# Altium schematic sheet AD936X_PMU.SchDoc(AD936X_PMU)
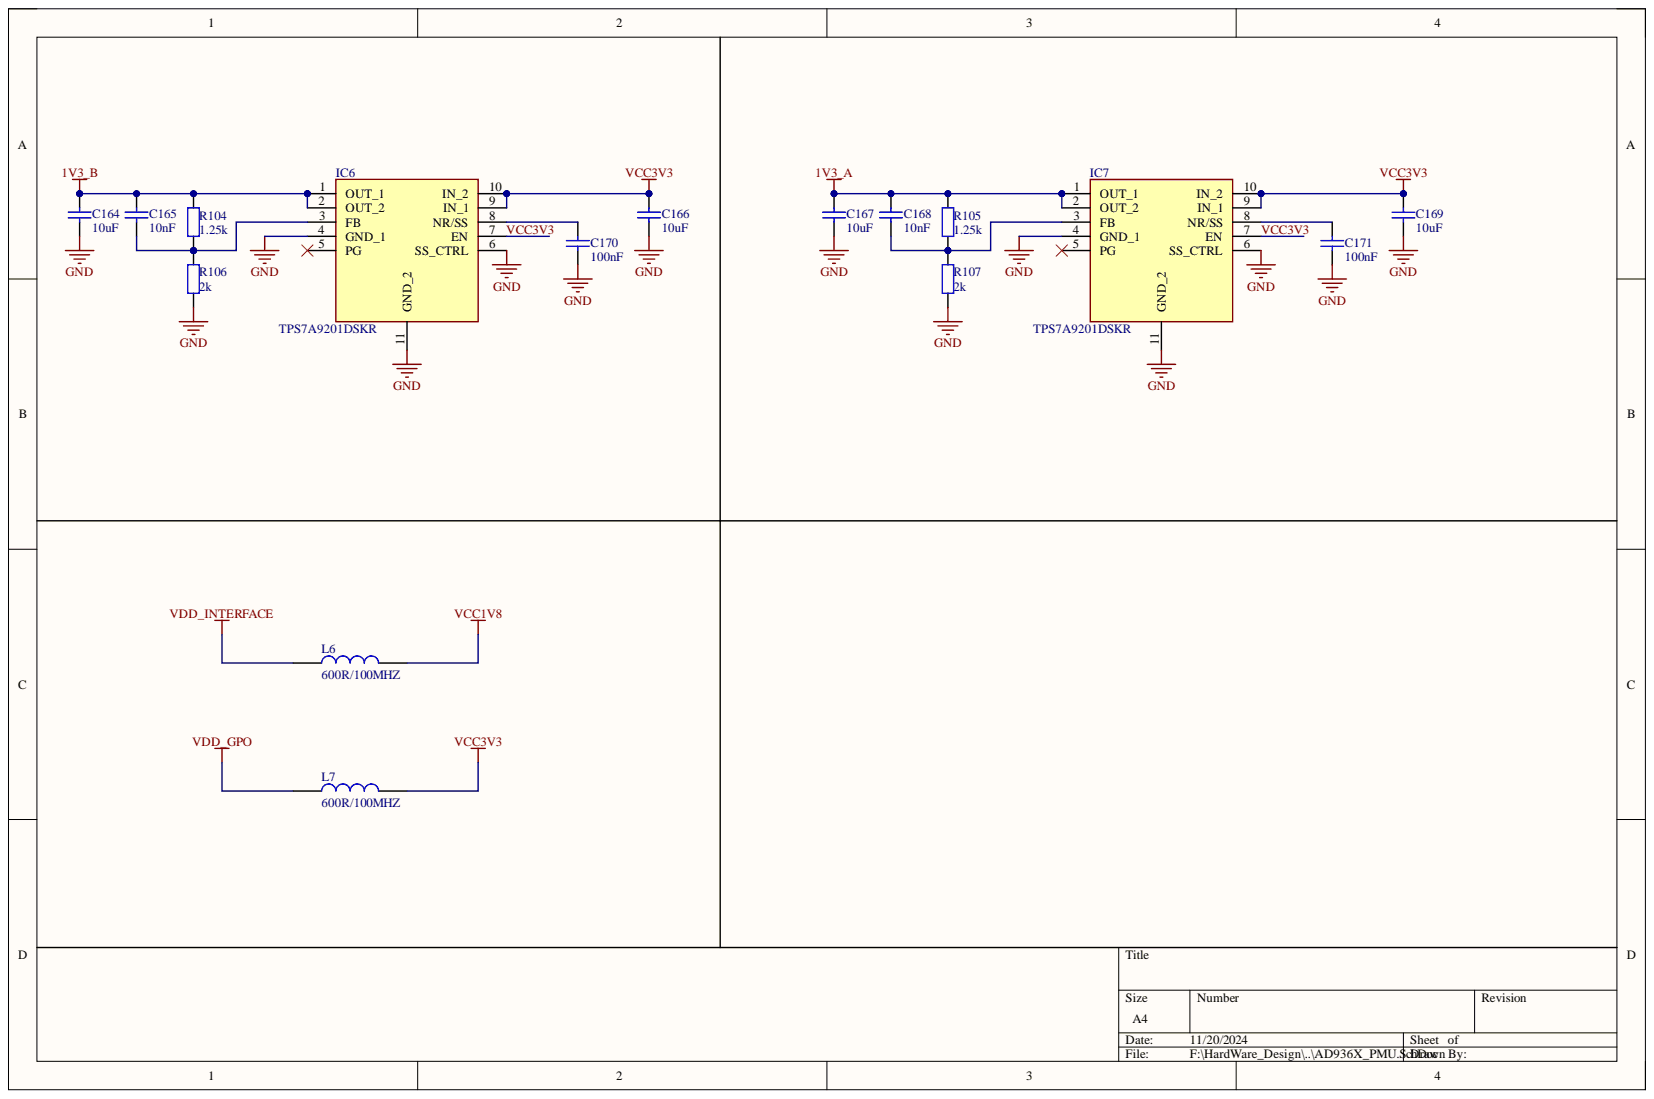
[diagram p0: AD936X_PMU.SchDoc]

## Parts
C164  10uF  [C 0603_L]
C165  10nF  [C 0402_L]
C166  10uF  [C 0603_L]
C167  10uF  [C 0603_L]
C168  10nF  [C 0402_L]
C169  10uF  [C 0603_L]
C170  100nF  [C 0402_L]
C171  100nF  [C 0402_L]
IC6  TPS7A9201DSKR  [SON50P250X250X80-11N-D]
IC7  TPS7A9201DSKR  [SON50P250X250X80-11N-D]
L6  600R/100MHZ  [L 0805]
L7  600R/100MHZ  [L 0805]
R104  1.25k  [R 0402_L]
R105  1.25k  [R 0402_L]
R106  2k  [R 0402_L]
R107  2k  [R 0402_L]

## Nets
1V3_A: C167.2 C168.2 IC7.1(OUT_1) IC7.2(OUT_2) R105.2
1V3_B: C164.2 C165.2 IC6.1(OUT_1) IC6.2(OUT_2) R104.2
GND: C164.1 C166.1 C167.1 C169.1 C170.1 C171.1 IC6.4(GND_1) IC6.6(SS_CTRL) IC6.11(GND_2) IC7.4(GND_1) IC7.6(SS_CTRL) IC7.11(GND_2) R106.1 R107.1
VCC1V8: L6.2
VDD_GPO: L7.1
VDD_INTERFACE: L6.1
VCC3V3: C166.2 C169.2 IC6.7(EN) IC6.9(IN_1) IC6.10(IN_2) IC7.7(EN) IC7.9(IN_1) IC7.10(IN_2) L7.2
NetC165_1: C165.1 IC6.3(FB) R104.1 R106.2
NetC168_1: C168.1 IC7.3(FB) R105.1 R107.2
NetC170_2: C170.2 IC6.8(NR/SS)
NetC171_2: C171.2 IC7.8(NR/SS)

Not connected: IC6 5(PG); IC7 5(PG)

## Library data of the parts
C164-C171 = Cap: 贴片电容; Component Kind: Standard; Library Name: A_Schlib.SchLib
  C164 C166 C167 C169: value: 10uF
  C165 C168: value: 10nF
  C170 C171: value: 100nF
IC6 IC7 = TPS7A9101DSKR: Integrated Circuit; Manufacturer_Name: Texas Instruments; Arrow Part Number: TPS7A9101DSKR; Arrow Price/Stock: https://www.arrow.com/en/products/tps7a9101dskr/texas-instruments?region=nac; Component Kind: Standard; Datasheet Link: http://www.ti.com/lit/ds/symlink/tps7a91.pdf; Height: 0.8mm; Manufacturer_Part_Number: TPS7A9101DSKR; Mouser Part Number: 595-TPS7A9101DSKR; Mouser Price/Stock: https://www.mouser.co.uk/ProductDetail/Texas-Instruments/TPS7A9101DSKR?qs=34RfhUjJmKdov7HQNWp7rg%3D%3D
L6 L7 = 电感: 包含功率; Component Kind: Standard; Library Name: A_Schlib.SchLib
R104-R107 = Res: 通用; Component Kind: Standard; Library Name: A_Schlib.SchLib
  R104 R105: value: 1.25k
  R106 R107: value: 2k
